# Altium schematic sheet Discovery Board.SchDoc("Discovery Board")
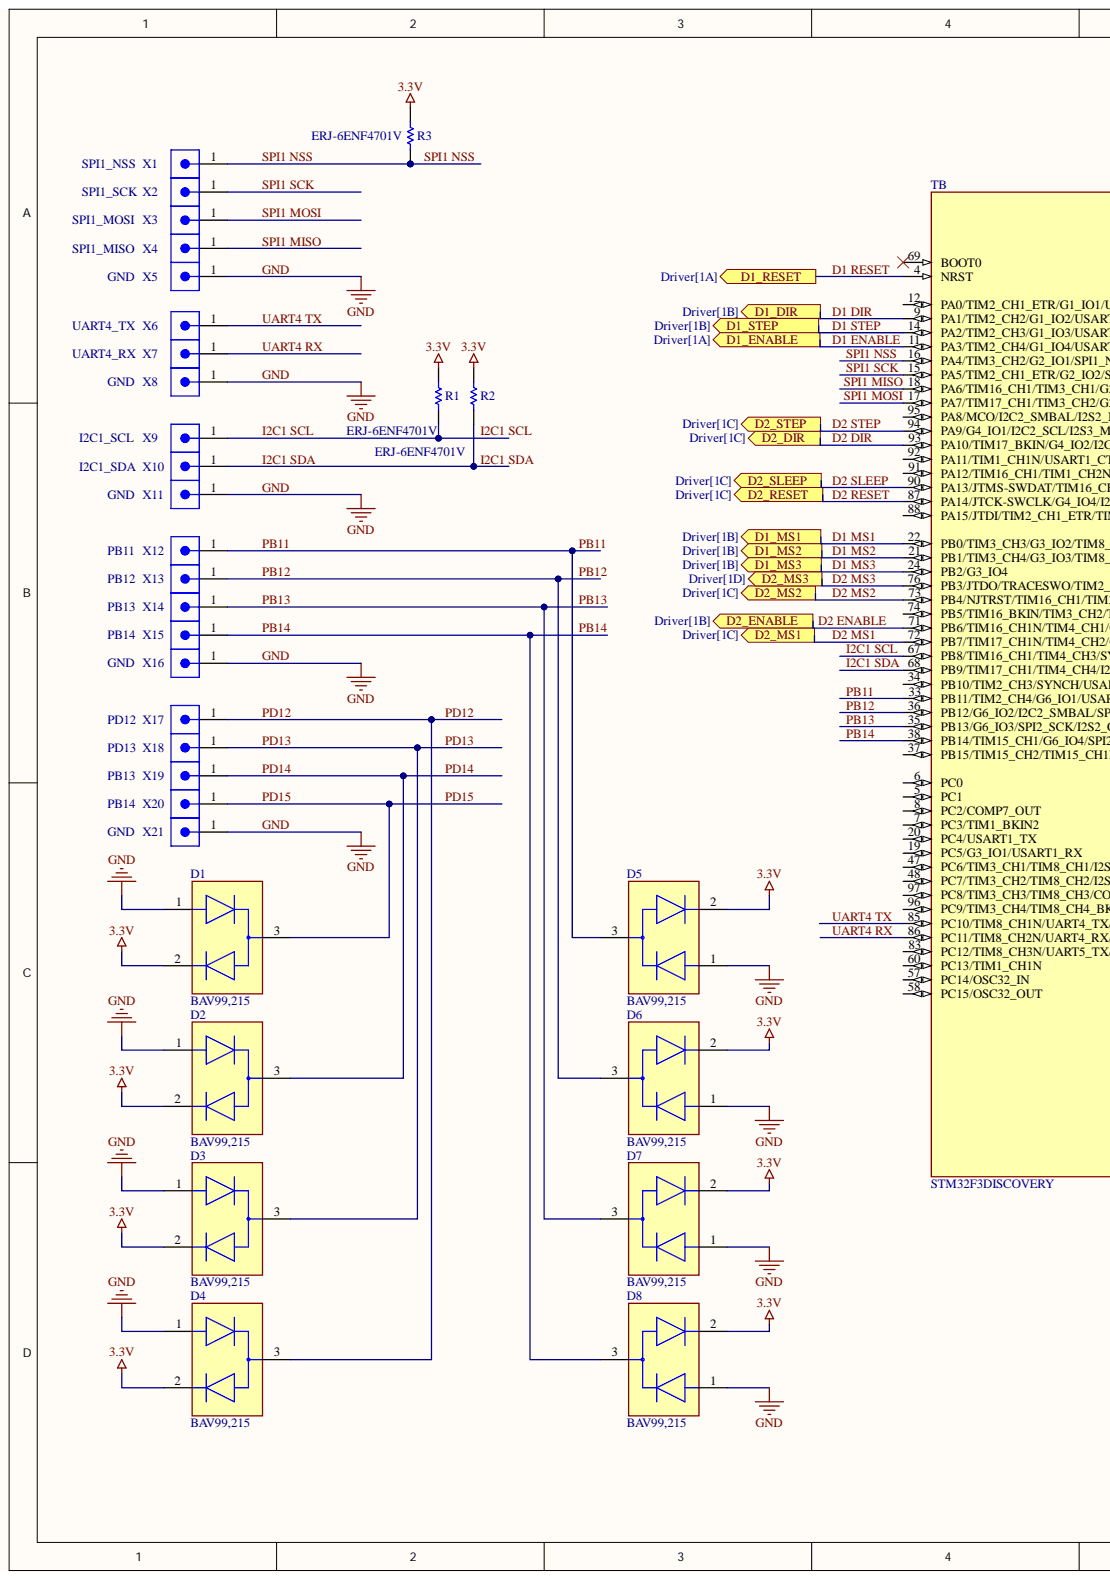
[diagram p0: Discovery Board.SchDoc, left]
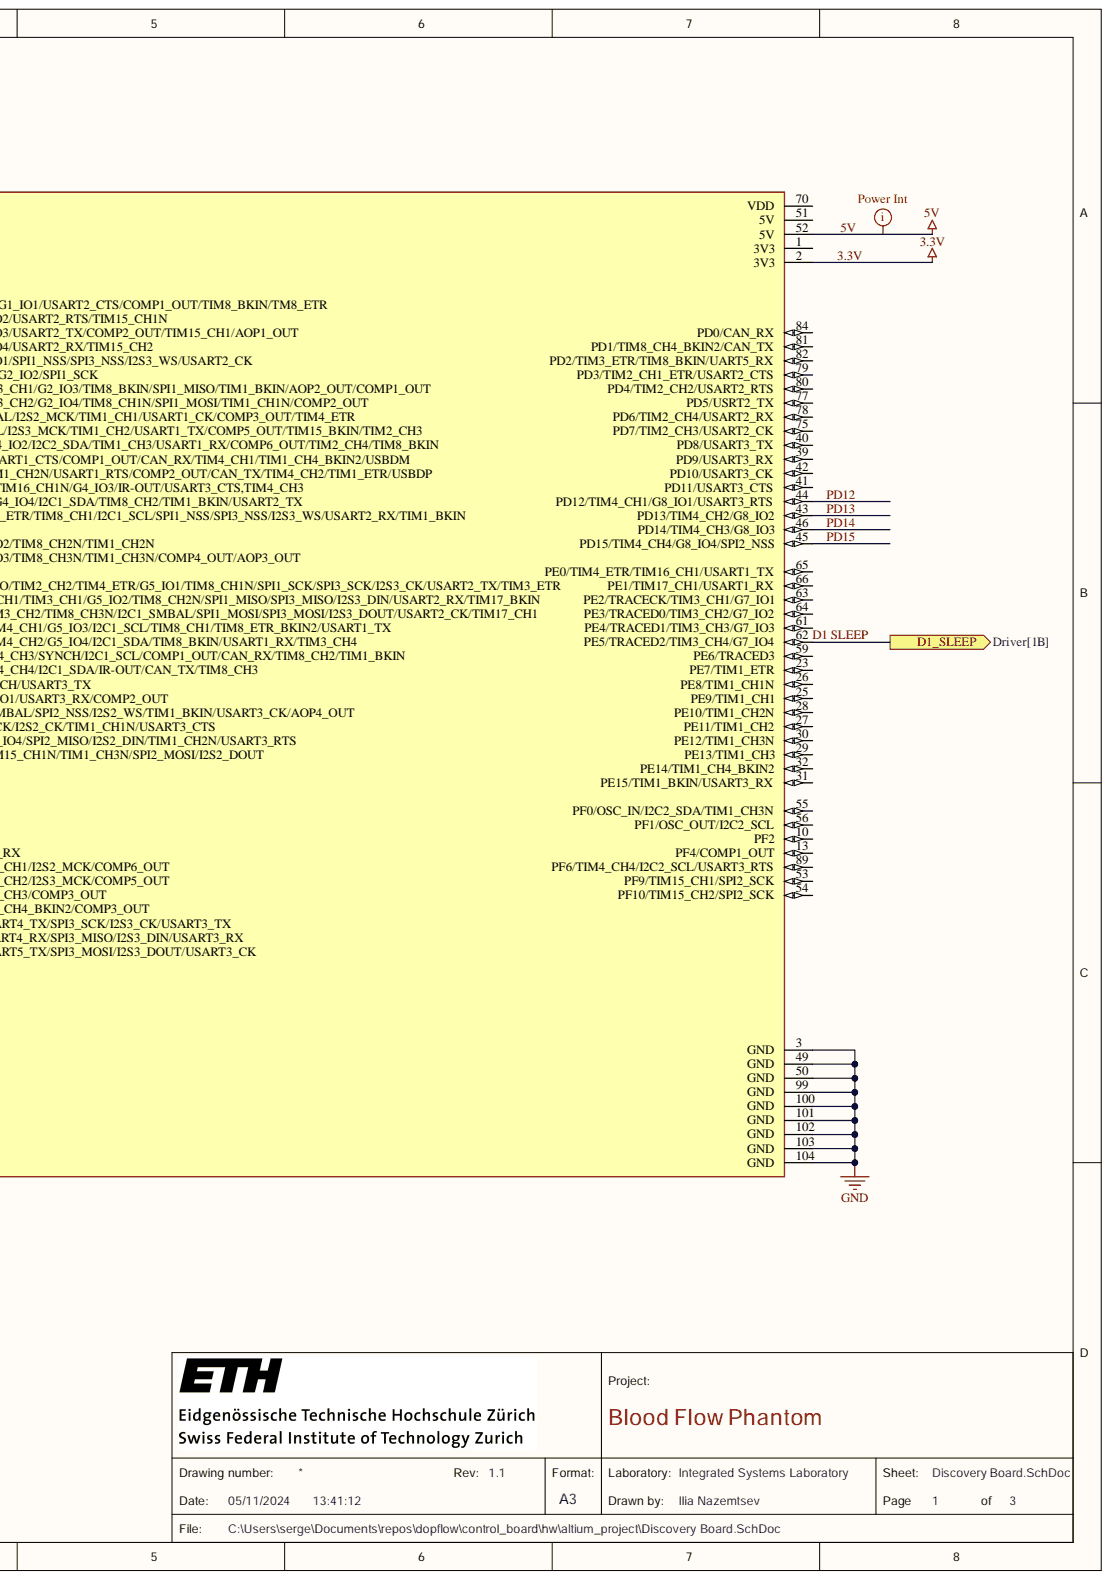
[diagram p1: Discovery Board.SchDoc, right]

## Parts
D1  BAV99,215  [FP-SOT23-MFG]
D2  BAV99,215  [FP-SOT23-MFG]
D3  BAV99,215  [FP-SOT23-MFG]
D4  BAV99,215  [FP-SOT23-MFG]
D5  BAV99,215  [FP-SOT23-MFG]
D6  BAV99,215  [FP-SOT23-MFG]
D7  BAV99,215  [FP-SOT23-MFG]
D8  BAV99,215  [FP-SOT23-MFG]
R1  ERJ-6ENF4701V  [RESC2013X70X40NL20T20]
R2  ERJ-6ENF4701V  [RESC2013X70X40NL20T20]
R3  ERJ-6ENF4701V  [RESC2013X70X40NL20T20]
TB  STM32F3DISCOVERY  [SHIELD_STM32F3DISCOVERY]
X1  SPI1_NSS  [68000-101HLF]
X2  SPI1_SCK  [68000-101HLF]
X3  SPI1_MOSI  [68000-101HLF]
X4  SPI1_MISO  [68000-101HLF]
X5  GND  [68000-101HLF]
X6  UART4_TX  [68000-101HLF]
X7  UART4_RX  [68000-101HLF]
X8  GND  [68000-101HLF]
X9  I2C1_SCL  [68000-101HLF]
X10  I2C1_SDA  [68000-101HLF]
X11  GND  [68000-101HLF]
X12  PB11  [68000-101HLF]  (value not drawn)
X13  PB12  [68000-101HLF]
X14  PB13  [68000-101HLF]
X15  PB14  [68000-101HLF]
X16  GND  [68000-101HLF]
X17  PD12  [68000-101HLF]
X18  PD13  [68000-101HLF]
X19  PB13  [68000-101HLF]
X20  PB14  [68000-101HLF]
X21  GND  [68000-101HLF]

## Ports
D1_DIR, D1_ENABLE, D1_MS1, D1_MS2, D1_MS3, D1_RESET, D1_SLEEP, D1_STEP, D2_DIR, D2_ENABLE, D2_MS1, D2_MS2, D2_MS3, D2_RESET, D2_SLEEP, D2_STEP

## Nets
3.3V: D1.2 D2.2 D3.2 D4.2 D5.2 D6.2 D7.2 D8.2 R1.1 R2.1 R3.1 TB.2(3V3)
5V: TB.52(5V)
D1 DIR: TB.9(PA1/TIM2_CH2/G1_IO2/USART2_RTS/TIM15_CH1N) ; port D1_DIR
D1 ENABLE: TB.11(PA3/TIM2_CH4/G1_IO4/USART2_RX/TIM15_CH2) ; port D1_ENABLE
D1 MS1: TB.22(PB0/TIM3_CH3/G3_IO2/TIM8_CH2N/TIM1_CH2N) ; port D1_MS1
D1 MS2: TB.21(PB1/TIM3_CH4/G3_IO3/TIM8_CH3N/TIM1_CH3N/COMP4_OUT/AOP3_OUT) ; port D1_MS2
D1 MS3: TB.24(PB2/G3_IO4) ; port D1_MS3
D1 RESET: TB.4(NRST) ; port D1_RESET
D1 SLEEP: TB.62(PE5/TRACED2/TIM3_CH4/G7_IO4) ; port D1_SLEEP
D1 STEP: TB.14(PA2/TIM2_CH3/G1_IO3/USART2_TX/COMP2_OUT/TIM15_CH1/AOP1_OUT) ; port D1_STEP
D2 DIR: TB.93(PA10/TIM17_BKIN/G4_IO2/I2C2_SDA/TIM1_CH3/USART1_RX/COMP6_OUT/TIM2_CH4/TIM8_BKIN) ; port D2_DIR
D2 ENABLE: TB.71(PB6/TIM16_CH1N/TIM4_CH1/G5_IO3/I2C1_SCL/TIM8_CH1/TIM8_ETR_BKIN2/USART1_TX) ; port D2_ENABLE
D2 MS1: TB.72(PB7/TIM17_CH1N/TIM4_CH2/G5_IO4/I2C1_SDA/TIM8_BKIN/USART1_RX/TIM3_CH4) ; port D2_MS1
D2 MS2: TB.73(PB4/NJTRST/TIM16_CH1/TIM3_CH1/G5_IO2/TIM8_CH2N/SPI1_MISO/SPI3_MISO/I2S3_DIN/USART2_RX/TIM17_BKIN) ; port D2_MS2
D2 MS3: TB.76(PB3/JTDO/TRACESWO/TIM2_CH2/TIM4_ETR/G5_IO1/TIM8_CH1N/SPI1_SCK/SPI3_SCK/I2S3_CK/USART2_TX/TIM3_ETR) ; port D2_MS3
D2 RESET: TB.87(PA14/JTCK-SWCLK/G4_IO4/I2C1_SDA/TIM8_CH2/TIM1_BKIN/USART2_TX) ; port D2_RESET
D2 SLEEP: TB.90(PA13/JTMS-SWDAT/TIM16_CH1N/G4_IO3/IR-OUT/USART3_CTS,TIM4_CH3) ; port D2_SLEEP
D2 STEP: TB.94(PA9/G4_IO1/I2C2_SCL/I2S3_MCK/TIM1_CH2/USART1_TX/COMP5_OUT/TIM15_BKIN/TIM2_CH3) ; port D2_STEP
GND: D1.1 D2.1 D3.1 D4.1 D5.1 D6.1 D7.1 D8.1 TB.3(GND) TB.49(GND) TB.50(GND) TB.99(GND) TB.100(GND) TB.101(GND) TB.102(GND) TB.103(GND) TB.104(GND) X5.1 X8.1 X11.1 X16.1 X21.1
I2C1 SCL: R1.2 TB.67(PB8/TIM16_CH1/TIM4_CH3/SYNCH/I2C1_SCL/COMP1_OUT/CAN_RX/TIM8_CH2/TIM1_BKIN) X9.1
I2C1 SDA: R2.2 TB.68(PB9/TIM17_CH1/TIM4_CH4/I2C1_SDA/IR-OUT/CAN_TX/TIM8_CH3) X10.1
PB11: D5.3 TB.33(PB11/TIM2_CH4/G6_IO1/USART3_RX/COMP2_OUT) X12.1
PB12: D6.3 TB.36(PB12/G6_IO2/I2C2_SMBAL/SPI2_NSS/I2S2_WS/TIM1_BKIN/USART3_CK/AOP4_OUT) X13.1
PB13: D7.3 TB.35(PB13/G6_IO3/SPI2_SCK/I2S2_CK/TIM1_CH1N/USART3_CTS) X14.1
PB14: D8.3 TB.38(PB14/TIM15_CH1/G6_IO4/SPI2_MISO/I2S2_DIN/TIM1_CH2N/USART3_RTS) X15.1
PD12: D4.3 TB.44(PD12/TIM4_CH1/G8_IO1/USART3_RTS) X17.1
PD13: D3.3 TB.43(PD13/TIM4_CH2/G8_IO2) X18.1
PD14: D2.3 TB.46(PD14/TIM4_CH3/G8_IO3) X19.1
PD15: D1.3 TB.45(PD15/TIM4_CH4/G8_IO4/SPI2_NSS) X20.1
SPI1 MISO: TB.18(PA6/TIM16_CH1/TIM3_CH1/G2_IO3/TIM8_BKIN/SPI1_MISO/TIM1_BKIN/AOP2_OUT/COMP1_OUT) X4.1
SPI1 MOSI: TB.17(PA7/TIM17_CH1/TIM3_CH2/G2_IO4/TIM8_CH1N/SPI1_MOSI/TIM1_CH1N/COMP2_OUT) X3.1
SPI1 NSS: R3.2 TB.16(PA4/TIM3_CH2/G2_IO1/SPI1_NSS/SPI3_NSS/I2S3_WS/USART2_CK) X1.1
SPI1 SCK: TB.15(PA5/TIM2_CH1_ETR/G2_IO2/SPI1_SCK) X2.1
UART4 RX: TB.86(PC11/TIM8_CH2N/UART4_RX/SPI3_MISO/I2S3_DIN/USART3_RX) X7.1
UART4 TX: TB.85(PC10/TIM8_CH1N/UART4_TX/SPI3_SCK/I2S3_CK/USART3_TX) X6.1

Not connected: TB 1(3V3) 5(PC1) 6(PC0) 7(PC3/TIM1_BKIN2) 8(PC2/COMP7_OUT) 10(PF2) 12(PA0/TIM2_CH1_ETR/G1_IO1/USART2_CTS/COMP1_OUT/TIM8_BKIN/TM8_ETR) 13(PF4/COMP1_OUT) 19(PC5/G3_IO1/USART1_RX) 20(PC4/USART1_TX) 23(PE7/TIM1_ETR) 25(PE9/TIM1_CH1) 26(PE8/TIM1_CH1N) 27(PE11/TIM1_CH2) 28(PE10/TIM1_CH2N) 29(PE13/TIM1_CH3) 30(PE12/TIM1_CH3N) 31(PE15/TIM1_BKIN/USART3_RX) 32(PE14/TIM1_CH4_BKIN2) 34(PB10/TIM2_CH3/SYNCH/USART3_TX) 37(PB15/TIM15_CH2/TIM15_CH1N/TIM1_CH3N/SPI2_MOSI/I2S2_DOUT) 39(PD9/USART3_RX) 40(PD8/USART3_TX) 41(PD11/USART3_CTS) 42(PD10/USART3_CK) 47(PC6/TIM3_CH1/TIM8_CH1/I2S2_MCK/COMP6_OUT) 48(PC7/TIM3_CH2/TIM8_CH2/I2S3_MCK/COMP5_OUT) 51(5V) 53(PF9/TIM15_CH1/SPI2_SCK) 54(PF10/TIM15_CH2/SPI2_SCK) 55(PF0/OSC_IN/I2C2_SDA/TIM1_CH3N) 56(PF1/OSC_OUT/I2C2_SCL) 57(PC14/OSC32_IN) 58(PC15/OSC32_OUT) 59(PE6/TRACED3) 60(PC13/TIM1_CH1N) 61(PE4/TRACED1/TIM3_CH3/G7_IO3) 63(PE2/TRACECK/TIM3_CH1/G7_IO1) 64(PE3/TRACED0/TIM3_CH2/G7_IO2) 65(PE0/TIM4_ETR/TIM16_CH1/USART1_TX) 66(PE1/TIM17_CH1/USART1_RX) 69(BOOT0) 70(VDD) 74(PB5/TIM16_BKIN/TIM3_CH2/TIM8_CH3N/I2C1_SMBAL/SPI1_MOSI/SPI3_MOSI/I2S3_DOUT/USART2_CK/TIM17_CH1) 75(PD7/TIM2_CH3/USART2_CK) 77(PD5/USRT2_TX) 78(PD6/TIM2_CH4/USART2_RX) 79(PD3/TIM2_CH1_ETR/USART2_CTS) 80(PD4/TIM2_CH2/USART2_RTS) 81(PD1/TIM8_CH4_BKIN2/CAN_TX) 82(PD2/TIM3_ETR/TIM8_BKIN/UART5_RX) 83(PC12/TIM8_CH3N/UART5_TX/SPI3_MOSI/I2S3_DOUT/USART3_CK) 84(PD0/CAN_RX) 88(PA15/JTDI/TIM2_CH1_ETR/TIM8_CH1/I2C1_SCL/SPI1_NSS/SPI3_NSS/I2S3_WS/USART2_RX/TIM1_BKIN) 89(PF6/TIM4_CH4/I2C2_SCL/USART3_RTS) 91(PA12/TIM16_CH1/TIM1_CH2N/USART1_RTS/COMP2_OUT/CAN_TX/TIM4_CH2/TIM1_ETR/USBDP) 92(PA11/TIM1_CH1N/USART1_CTS/COMP1_OUT/CAN_RX/TIM4_CH1/TIM1_CH4_BKIN2/USBDM) 95(PA8/MCO/I2C2_SMBAL/I2S2_MCK/TIM1_CH1/USART1_CK/COMP3_OUT/TIM4_ETR) 96(PC9/TIM3_CH4/TIM8_CH4_BKIN2/COMP3_OUT) 97(PC8/TIM3_CH3/TIM8_CH3/COMP3_OUT)

## Library data of the parts
D1-D8 = CMP-14399-000006-1: DIODE ARRAY GP 100V 215MA SOT23; Component Kind: Standard; Library Name: Altium Content Vault
R1-R3 = CMP-2001-00550-5: Chip Resistor, 4.7 KOhm, +/- 1%, 0.125 W, -55 to 155 degC, 0805 (2012 Metric), RoHS, Tape and Reel; Manufacturer: Panasonic; Voltage Rating: 150 V; Component Kind: Standard; ComponentLink1Description: Manufacturer URL; ComponentLink1URL: http://www.panasonic.com/; ComponentLink2Description: Datasheet; ComponentLink2URL: http://industrial.panasonic.com/cdbs/www-data/pdf/RDA0000/AOA0000C86.pdf; DatasheetVersion: 04/2015; Height: 0.6 mm; Length: 2 mm; Library Name: Altium Content Vault; Max Operating Temperature: 155 degC; Min Operating Temperature: -55 degC; Mount: Surface Mount; Mounting Technology: Surface Mount; Package Quantity: 5000; PackageDescription: 2-Pin Surface Mount Device, Body 2 x 1.25 mm; PackageReference: 0805; Packaging: Tape and Reel; Pins: 2; REACH SVHC: Yes; RoHSCompliant: true
TB = STM32F3DISCOVERY: STM32F303VCT6 Discovery STM32F3 ARMÂ® CortexÂ®-M4 MCU 32-Bit Embedded Evaluation Board; MF: STMicroelectronics; Availability: Unavailable; Component Kind: Standard; Library Name: SnapEDAsch.SchLib; MP: STM32F3DISCOVERY; Package: LQFP-100 STMicroelectronics; Price: None; SnapEDA_Link: https://www.snapeda.com/parts/STM32F3DISCOVERY/STMicroelectronics/view-part/10815/?ref=snap
X1-X21 = CMP-00008-5: 1 Positions Header, Unshrouded Connector  Through Hole Gold or Gold, GXT™; Manufacturer: Amphenol FCI; Connector Type: Male Pin; Current Rating: 3A; Gender: Male; Insulation Resistance: 5GR; Mating Post Length: 5.84mm; Max Voltage Rating (AC): 1.5kV; Number of Contacts: 1; Pitch: 2.54mm; Value: 1000Meg; Colour: Black; Component Kind: Standard; ComponentLink1Description: 68000-274334-5147816.pdf; ComponentLink1URL: https://datasheet.ciiva.com/5147/68000-274334-5147816.pdf; ComponentLink2Description: 70236886-14643004.pdf; ComponentLink2URL: https://datasheet.ciiva.com/14643/70236886-14643004.pdf; ComponentLink3Description: 68000-10150538.pdf; ComponentLink3URL: https://datasheet.ciiva.com/10150/68000-10150538.pdf; ComponentLink4Description: 68000-731073.pdf; ComponentLink4URL: https://datasheet.ciiva.com/731/68000-731073.pdf; Contact Gender: Male; Contact Material: Bronze; Contact Mating Length: 0.23inch; Contact Plating: Nickel,Gold,GXT; Depth: 2.41mm; Flammability Rating: UL94 V-0; Housing Colour: Black; Housing Material: Thermoplastic; Length: 2.54mm; Library Name: ETHZ Vault; Manufacturer Part Number: 68000-101HLF; Max Operating Temperature: 125°C; Min Operating Temperature: -65°C; Number of Positions: 1; Number of Rows: 1; Orientation: Straight; Package Quantity: 100; Packaging: Bulk; Radiation Hardening: No; Simulation: SIM-001-0001-1; Supplier: Digikey; Supplier Part Number: 609-3460-ND; Termination: Solder; Termination Post Length: 2.41mm; Voltage Rating: 1.5kV; Voltage Rating (DC): 1.5kV
